# Altium schematic sheet main.SchDoc(main)
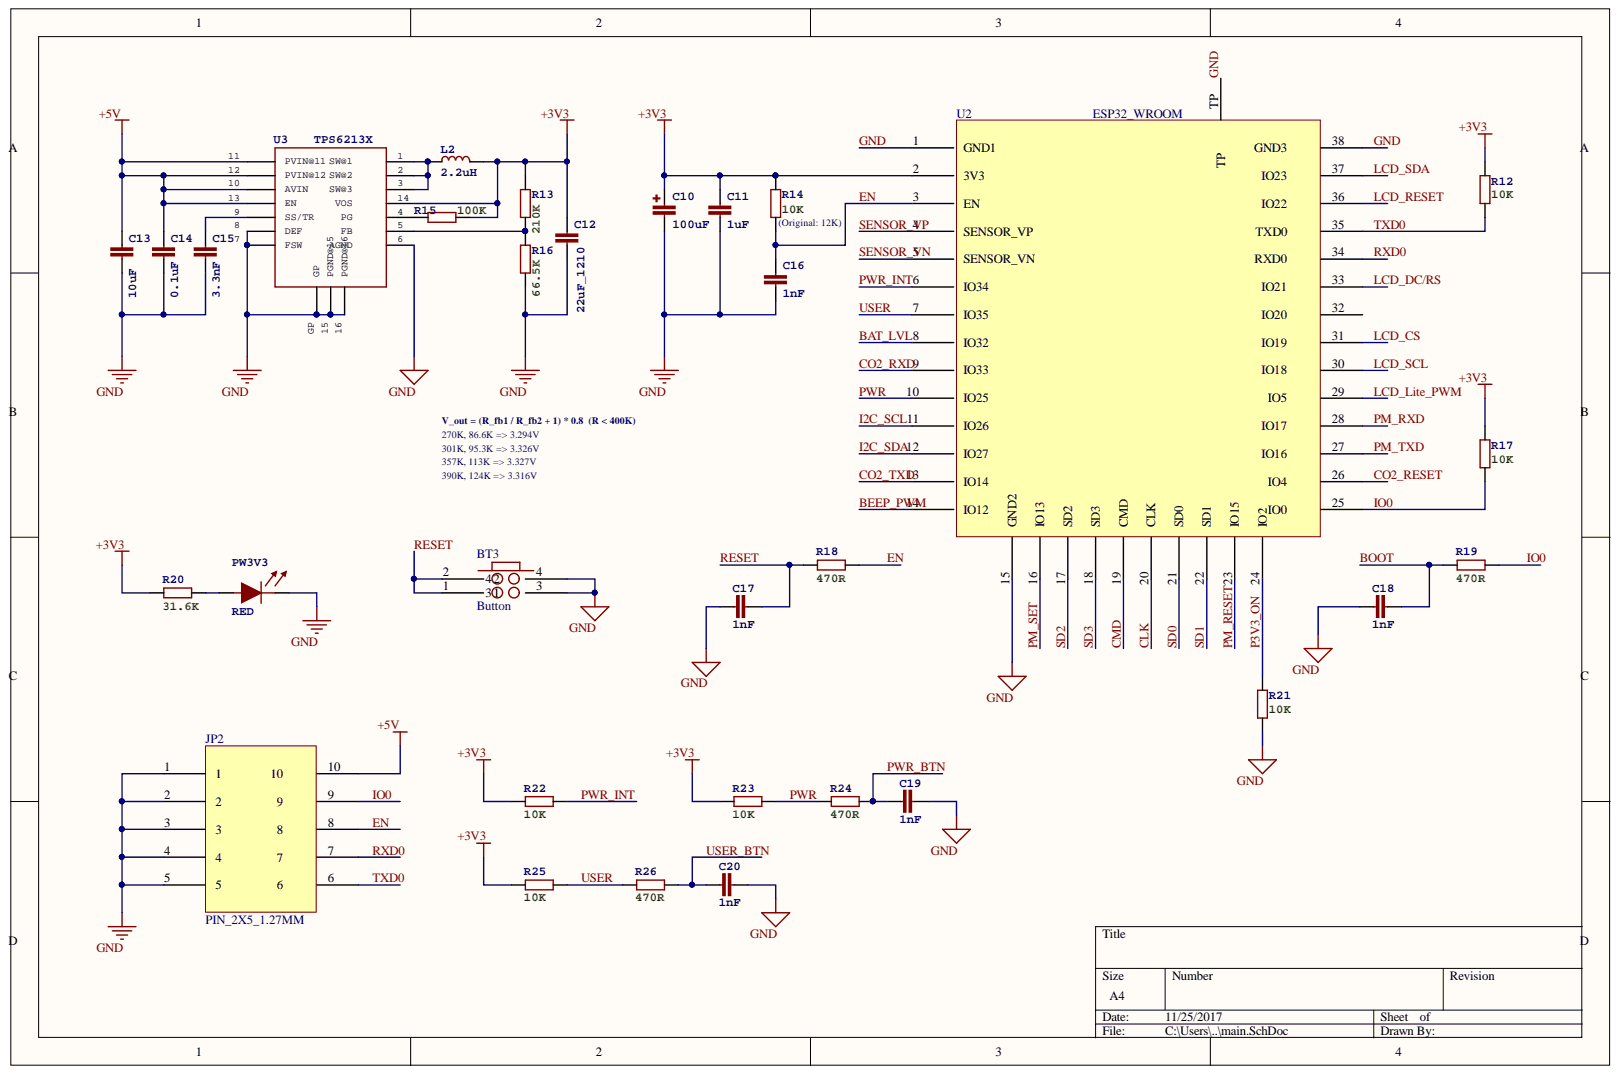
[diagram p0: main.SchDoc]

## Parts
BT3  Button  [BUTTON_SMT_4x4x1.5]
C10  100uF  [C1206-TAN-P]
C11  1uF  [C0603]
C12  22uF_1210  [C1210]
C13  10uF  [C0603]
C14  0.1uF  [C0603]
C15  3.3nF  [C0603]
C16  1nF  [C0603]
C17  1nF  [C0603]
C18  1nF  [C0603]
C19  1nF  [C0603]
C20  1nF  [C0603]
JP2  PIN_2X5_1.27MM  [PIN_2x5_1.27]
L2  2.2uH  [INDUCTOR_5X5MM_TDK_VLC5045]
PW3V3  RED  [LED0603]
R12  10K  [R0603]
R13  210K  [R0603]
R14  10K  [R0603]
R15  100K  [R0603]
R16  66.5K  [R0603]
R17  10K  [R0603]
R18  470R  [R0603]
R19  470R  [R0603]
R20  31.6K  [R0603]
R21  10K  [R0603]
R22  10K  [R0603]
R23  10K  [R0603]
R24  470R  [R0603]
R25  10K  [R0603]
R26  470R  [R0603]
U2  ESP32_WROOM  [ESP-WROOM32]
U3  TPS6213X  [QFN16-3X3MM_TI]

## Nets
+3V3: C10.1 C11.1 C12.1 L2.P$2 R12.1 R13.2 R14.1 R15.2 R17.1 R20.2 R22.2 R23.2 R25.2 U2.2(3V3) U3.14
+5V: C13.1 C14.1 JP2.10 U3.10(AVIN) U3.11 U3.12 U3.13(EN)
BAT_LVL: U2.8(IO32)
BEEP_PWM: U2.14(IO12)
BOOT: C18.1 R19.2
CLK: U2.20(CLK)
CMD: U2.19(CMD)
CO2_RESET: U2.26
CO2_RXD: U2.9(IO33)
CO2_TXD: U2.13(IO14)
EN: C16.1 JP2.8 R14.2 R18.1 U2.3(EN)
GND: BT3.3 BT3.4 C10.2 C11.2 C12.2 C13.2 C14.2 C15.2 C16.2 C17.2 C18.2 C19.1 C20.1 JP2.1 JP2.2 JP2.3 JP2.4 JP2.5 PW3V3.1 R16.1 R21.2 U2.1(GND1) U2.15(GND2) U2.38 U2.TP U3.6 U3.7(FSW) U3.8(DEF) U3.15(PGND@15) U3.16(PGND@16) U3.GP
I2C_SCL: U2.11(IO26)
I2C_SDA: U2.12(IO27)
IO0: JP2.9 R17.2 R19.1 U2.25
LCD_CS: U2.31
LCD_DC/RS: U2.33
LCD_LITE_PWM: U2.29
LCD_RESET: U2.36
LCD_SCL: U2.30
LCD_SDA: U2.37
P3V3_ON: R21.1 U2.24(IO2)
PM_RESET: U2.23(IO15)
PM_RXD: U2.28
PM_SET: U2.16(IO13)
PM_TXD: U2.27
PWR: R23.1 R24.2 U2.10(IO25)
PWR_BTN: C19.2 R24.1
PWR_INT: R22.1 U2.6(IO34)
RESET: BT3.1(3_1) BT3.2(4_2) C17.1 R18.2
RXD0: JP2.7 U2.34
SD0: U2.21(SD0)
SD1: U2.22(SD1)
SD2: U2.17(SD2)
SD3: U2.18(SD3)
SENSOR_VN: U2.5(SENSOR_VN)
SENSOR_VP: U2.4(SENSOR_VP)
TXD0: JP2.6 R12.2 U2.35
USER: R25.1 R26.2 U2.7(IO35)
USER_BTN: C20.2 R26.1
NetC15_1: C15.1 U3.9(SS/TR)
NetL2_P$1: L2.P$1 U3.1 U3.2 U3.3
NetPW3V3_2: PW3V3.2 R20.1
NetR13_1: R13.1 R16.2 U3.5
NetR15_1: R15.1 U3.4

Not connected: U2 32

## Library data of the parts
BT3 = BUTTON_4x4x1.5: Component Kind: Standard; Library Name: shenghua.SCHLIB
C10 = C1206-TANA-P: Component Kind: Standard; Library Name: shenghua.SCHLIB
C11 C13-C20 = C-EUC0603: CAPACITOR, European symbol; Component Kind: Standard; Library Name: shenghua.SCHLIB
C12 = C-EUC1210: Component Kind: Standard; Library Name: shenghua.SCHLIB
L2 = INDUCTORTDK_VLC5045: Component Kind: Standard; Library Name: shenghua.SCHLIB
PW3V3 = LED0603: Component Kind: Standard; Library Name: shenghua.SCHLIB
R12-R26 = R-EU_R0603: Component Kind: Standard; Library Name: shenghua.SCHLIB
U2 = ESP_WROOM32_MODULE: Component Kind: Standard; Library Name: shenghua.SCHLIB
U3 = TPS6213X: 3-17V, 3A Step Down Converter; Component Kind: Standard; Library Name: shenghua.SCHLIB
JP2 = PIN_2X5_1.27MM: Component Kind: Standard; Library Name: shenghua.SCHLIB
